# Altium schematic sheet 1-Top.SchDoc(1-Top)
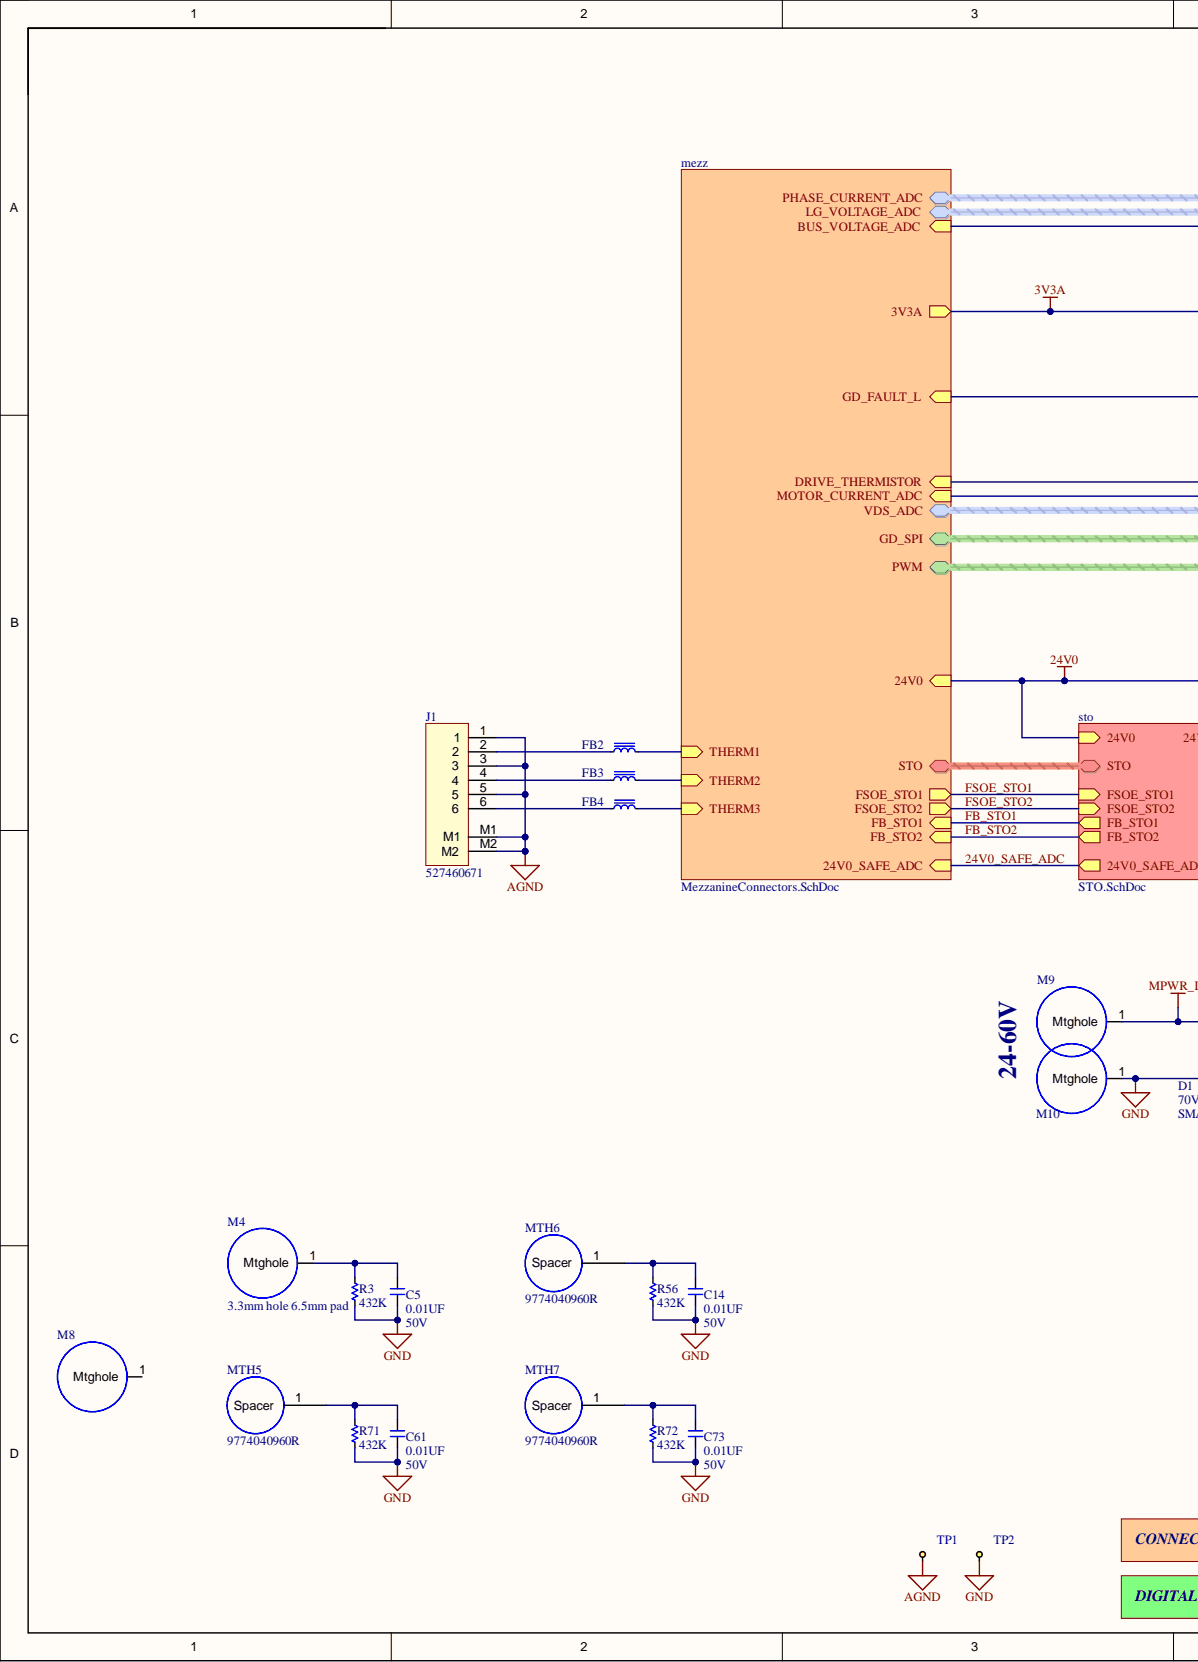
[diagram p0: 1-Top.SchDoc, left]
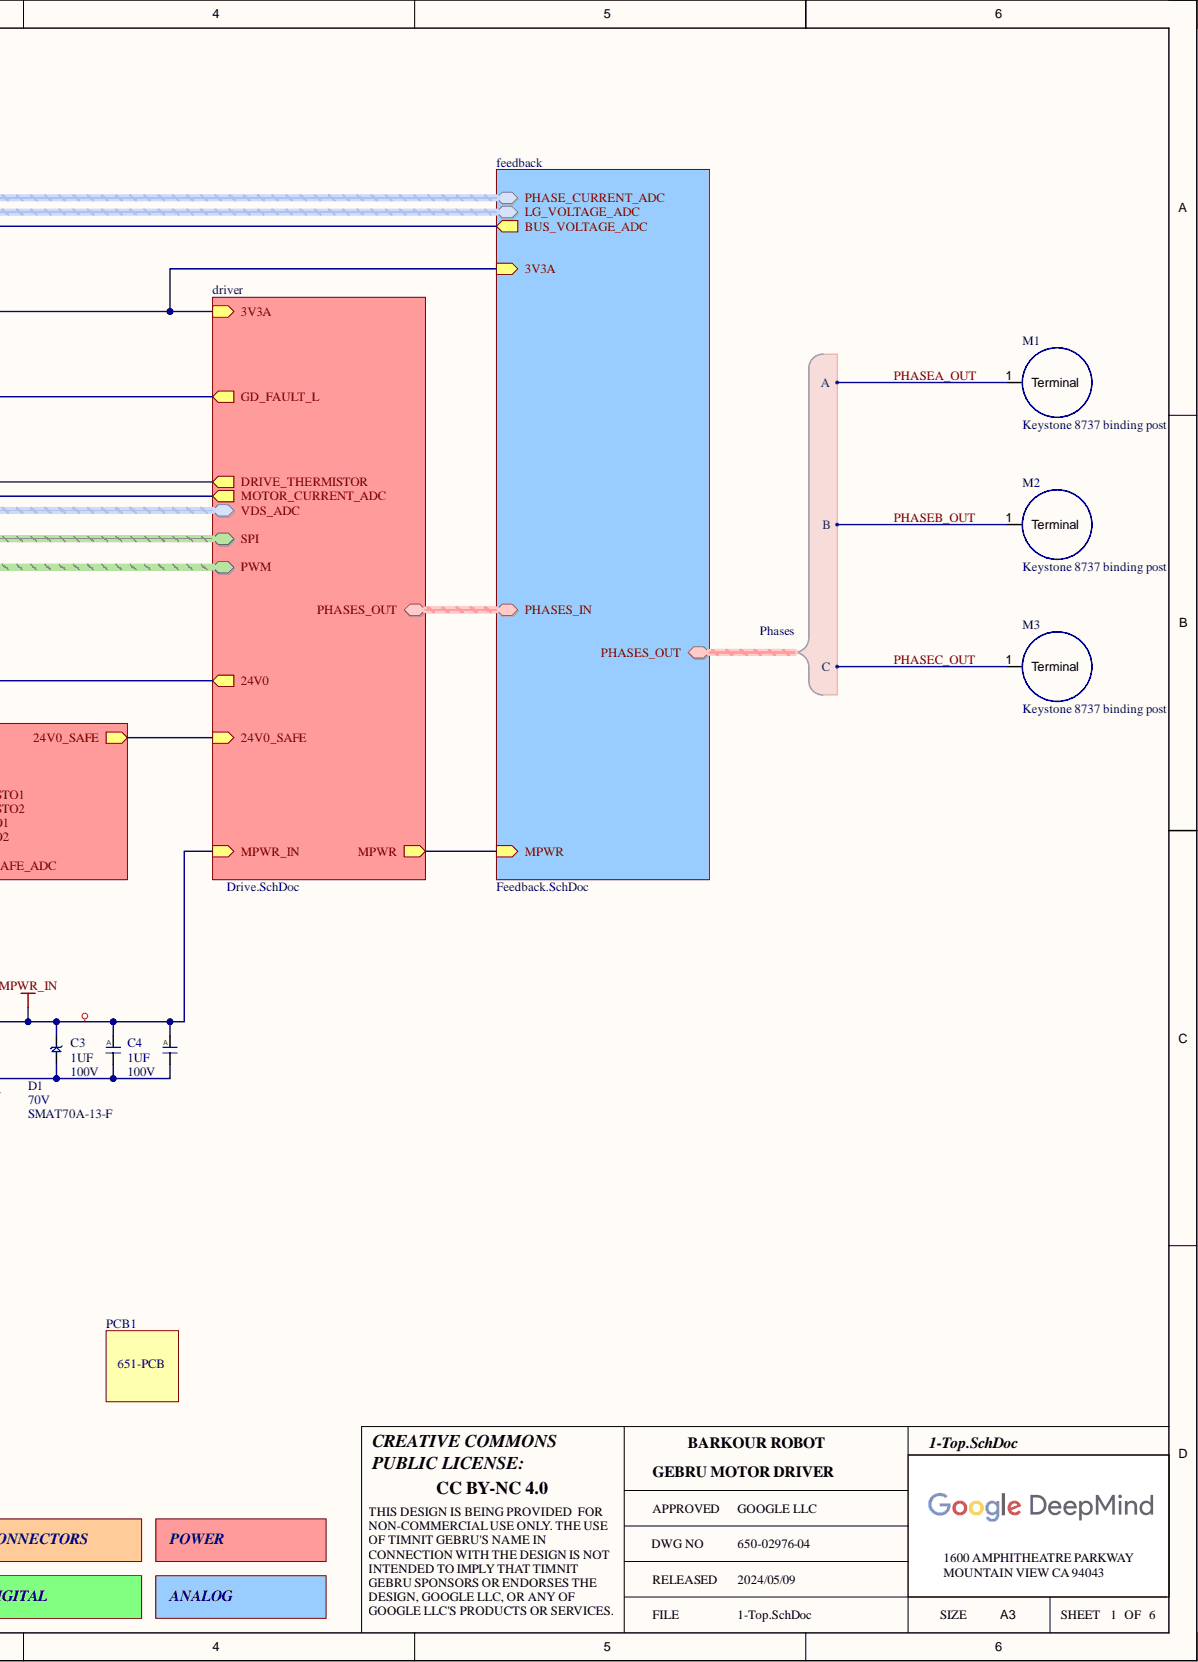
[diagram p1: 1-Top.SchDoc, right]

## Parts
C3  value 1UF  Voltage: 100V  [C0805]  (hidden comment: __Template_Cap_Auto)
C4  value 1UF  Voltage: 100V  [C0805]  (hidden comment: __Template_Cap_Auto)
C5  value 0.01UF  Voltage: 50V  [C0402]  (hidden comment: __Template_Cap)
C14  value 0.01UF  Voltage: 50V  [C0402]  (hidden comment: __Template_Cap)
C61  value 0.01UF  Voltage: 50V  [C0402]  (hidden comment: __Template_Cap)
C73  value 0.01UF  Voltage: 50V  [C0402]  (hidden comment: __Template_Cap)
D1  value SMAT70A-13-F  Voltage - Clamping (Max) @ Ipp: 100V  Voltage - DC Reverse (VR) (MAX): 70V  [SMA_B130-13-F]  (hidden comment: __Template_Diode_TVS_A/K)
FB2  LAIRD MI0603L221R-10  [INDC160X80X105L30N]  (value not drawn)
FB3  LAIRD MI0603L221R-10  [INDC160X80X105L30N]  (value not drawn)
FB4  LAIRD MI0603L221R-10  [INDC160X80X105L30N]  (value not drawn)
J1  MFG P/N: 527460671  [MOLEX_FPC_527460671]
M1  Keystone 8737 binding post  [Keystone_8737]  (no BOM)
M2  Keystone 8737 binding post  [Keystone_8737]  (no BOM)
M3  Keystone 8737 binding post  [Keystone_8737]  (no BOM)
M4  3.3mm hole 6.5mm pad  [3.3HOLE6.5PAD]  (no BOM)
M8  3.7mm hole 5mm pad  [3.7hole5pad]  (value not drawn)
M9  1.3mm hole 5mm pad  [1.7hole5padSQ]  (value not drawn)  (no BOM)
M10  1.3mm hole 5mm pad  [1.7hole5padRD]  (value not drawn)  (no BOM)
MTH5  9774040960R  [9774040960R]
MTH6  9774040960R  [9774040960R]
MTH7  9774040960R  [9774040960R]
PCB1  (no value)  (mechanical)
R3  value 432K  [R0805]
R56  value 432K  [R0805]
R71  value 432K  [R0805]
R72  value 432K  [R0805]
TP1  TEST_KEYSTONE5001  [TP_KEYSTONE_5001]  (value not drawn)
TP2  TEST_KEYSTONE5001  [TP_KEYSTONE_5001]  (value not drawn)

## Nets
AGND: J1.1 J1.3 J1.5 J1.M1 J1.M2 TP1.1
GND: C3.2 C4.2 C5.2 C14.2 C61.2 C73.2 D1.A M10.1 R3.1 R56.1 R71.1 R72.1 TP2.1
MPWR_IN: C3.1 C4.1 D1.K M9.1
PHASEA_OUT: M1.1
PHASEB_OUT: M2.1
PHASEC_OUT: M3.1
NetC5_1: C5.1 M4.1 R3.2
NetC14_1: C14.1 MTH6.1 R56.2
NetC61_1: C61.1 MTH5.1 R71.2
NetC73_1: C73.1 MTH7.1 R72.2
NetFB2_1: FB2.1 J1.2
NetFB2_2: FB2.2
NetFB3_1: FB3.1 J1.4
NetFB3_2: FB3.2
NetFB4_1: FB4.1 J1.6
NetFB4_2: FB4.2
NetM8_1: M8.1
3V3A:
24V0:
24V0_SAFE_ADC:
FB_STO1:
FB_STO2:
FSOE_STO1:
FSOE_STO2:
N00001:
N00002:
N00003:
N00004:
N00005:
N00006:
N00007:
N00008:
N00009:
N00010:
N00011:
N00012:
N00013:
N00014:
N00015:
N00016:
N00017:
N00018:
N00019:
N00020:
N00021:
N00022:
N00023:
N00024:
N00025:
N00026:
N00027:
N00028:
N00029:
N00030:
N00031:
N00032:
N00033:

## Library data of the parts
C3 C4 = 1093: CAP, CER, X7S, 1uF, 20%, 100V, 0805; COMMON: 150-01093-00; Database Table Name: Capacitors; EES: 150-04579-00; MFG: TDK; MFG P/N: CGA4J3X7S2A105M125AE; PROXY: 150-03473-00; XPN: XS-10001040; Component Kind: Standard; ComponentLink1Description: Datasheet; Dielectric: X7S; Gecko: 150-01093-00; Height: 1.5mm T; ID: 1093; Library Name: AltiumReleaseLibDB.DBLib; LOON: 150-01093-00; Package: 0805; Status: Released; Tolerance: 20%
C5 C14 C61 C73 = 625: CAP, CER, X7R, 0.01uF, 50V, 20%, 0402, 0.56mm T; COMMON: 150-00227-00; Database Table Name: Capacitors; EES: 150-04592-00; MFG: KEMET; MFG P/N: C0402C103M5RACTU; Component Kind: Standard; ComponentLink1Description: Datasheet; Dielectric: X7R; Gecko: 150-00227-00; Height: 0.56mm T; ID: 625; Library Name: AltiumReleaseLibDB.DBLib; LOON: 150-00227-00; Package: 0402; Status: Released; Tolerance: 20%; XPN: 150-00227-00
D1 = 200: DIODE, TVS, UNI-DIR, 70VR, 100VCL, 400W, 140PF @1MHZ, SMA; Database Table Name: Diodes; MFG: Diodes Incorporated; PROXY: 480-01326-00; Category: Diode; Component Kind: Standard; ComponentLink1Description: Datasheet; ComponentLink1URL: https://www.diodes.com/assets/Datasheets/ds30213.pdf; Diode Type: TVS; HelpURL: https://www.digikey.in/product-detail/en/diodes-incorporated/SMAT70A-13-F/SMAT70A-FDICT-ND/804910; ID: 200; Library Name: AltiumReleaseLibDB.DBLib; MFG P/N: SMAT70A-13-F; Mounting Type: SMD; Operating Temperature – Junction: -55°C ~ 150°C (TJ); Package: SMA; RoHS Compliant: Yes; Status: Released; Supplier 1: Digi-Key; Supplier Part Number 1: SMAT70A-FDICT-ND
FB2-FB4 = f5bd4cf1-070f-4480-bf1a-f8cba0e78574: FERRITE BEAD 220 OHM 0603 1LN; Manufacturer: Laird Technologies; Current Rating: 2A; Database Table Name: Filters; Grade: Commercial; Value: 220 Ohms @ 100 MHz; Category: Ferrite Beads; Component Kind: Standard; ComponentId: f5bd4cf1-070f-4480-bf1a-f8cba0e78574; ComponentLink1Description: Datasheet; ComponentLink1URL: https://media.digikey.com/pdf/Data%20Sheets/Laird%20Technologies/EMI_Filtering_and_RF_Inductors.pdf; Library Name: Components.DbLib; Manufacturer Part Number: MI0603L221R-10; Operating Temperature: -40 °C ~ 125 °C; Package: Inductor, 0603; Status: Preliminary; Storage Temperature: -40 °C ~ 125 °C
J1 = 1210: CONN, FFC, RA, 1x6 P, 0.5MM PITCH, 2.3MM H, GOLD, SMD; Current Rating: 0.5A; Database Table Name: Connectors; EES: 210-04996-00; MFG: Molex; Number of Positions: 6; Sub Assy Qty 2: 0; Voltage, Rated: 50V; Component Kind: Standard; ComponentLink1Description: Datasheet; ComponentLink1URL: https://www.molex.com/pdm_docs/sd/527460671_sd.pdf; Connector Type: FFC; Features: Contacts, Bottom; Height: 2.3mm; HelpURL: https://www.digikey.com/en/products/detail/molex/0527460671/2074148?s=N4IgTCBcDaIKxgOwBYBsAGViCMIC6AvkA; ID: 1210; Library Name: AltiumReleaseLibDB.DBLib; Mounting Type: Surface Mount; Number of Rows: 1; Operating Temperature: -20°C ~ 85°C; Orientation: Right Angle; Pitch: 0.5mm; RoHS Compliant: Yes; Status: Released; Sub Assy Qty 3: 0; Sub Assy Qty 4: 0; Supplier 1: Digi-Key; Supplier Part Number 1: WM7977TR-ND
M1-M3 = Keystone_8737_post: 1.5mm hole 5.6mm pad; Library Name: MiscSch1.SchLib
M4 = MtgHole_2: Description: 3.3mm hole 6.5mm pad; Library Name: MiscSch1.SchLib
M8 = MtgHole: Component Kind: Standard; Description: 3.7mm hole 5mm pad; Library Name: MiscSch1.SchLib
M9 M10 = MtgHole_1: Description: 1.3mm hole 5mm pad; Library Name: MiscSch1.SchLib
MTH5-MTH7 = Wurth_4mm_Spacer_9774040960R: Spacer Material: Steel Mounting: SMT Series: WA-SMST For ø: 3.3 mm; Manufacturer: Wurth Electronics; Inside Diameter: 0.13inch; Outside Diameter: 0.236inch; Plating: Tin; Threaded/Unthreaded: Unthreaded; Category: Spacers, Standoffs; Component Kind: Standard; ComponentLink1Description: http://www.farnell.com/datasheets/1960394.pdf?src-supplier=Farnell; ComponentLink1URL: http://www.farnell.com/datasheets/1960394.pdf?src-supplier=Farnell; ComponentLink2Description: http://www.mouser.com/ds/2/445/9774040960R-611108.pdf?src-supplier=Mouser; ComponentLink2URL: http://www.mouser.com/ds/2/445/9774040960R-611108.pdf?src-supplier=Mouser; ComponentLink3Description: https://media.digikey.com/pdf/Data%20Sheets/Wurth%20Electronics%20PDFs/WA-SMSx_Performance_Flyer.pdf?src-supplier=Digi-Key; ComponentLink3URL: https://media.digikey.com/pdf/Data%20Sheets/Wurth%20Electronics%20PDFs/WA-SMSx_Performance_Flyer.pdf?src-supplier=Digi-Key; ComponentLink4Description: http://www.farnell.com/datasheets/1960394.pdf?src-supplier=Element14; ComponentLink4URL: http://www.farnell.com/datasheets/1960394.pdf?src-supplier=Element14; ComponentLink5Description: http://www.farnell.com/datasheets/1960394.pdf?src-supplier=Newark; ComponentLink5URL: http://www.farnell.com/datasheets/1960394.pdf?src-supplier=Newark; Length: 0.213inch; Library Name: MiscSch1.SchLib; Manufacturer Part Number: 9774040960R; Material: Steel; Pricing 1: 1=1.79000, 10=1.71600, 50=1.50700, 100=1.40200 (USD); REACH SVHC: Unknown; RoHS: Yes; Stock 1: 190; Supplier 1: Digi-Key; Supplier Part Number 1: 732-7092-1-ND
PCB1 = 110: PCB; Database Table Name: Miscellaneous; FindNumber: 010; Level: 1; Org: ORG - REP; ID: 110; Library Name: AltiumReleaseLibDB.DBLib; ProductLine: Proxy; Status: In Development; Type: PCB
R3 R56 R71 R72 = 5492: Resistor, 432K Ohms, 0805, 0.125W, ±1%; COMMON: 470-08723-00; Database Table Name: Resistors; MFG: YAGEO; MFG P/N: RC0805FR-07432KL; Power: 1/8W; XPN: XS-10019230; Component Kind: Standard; Gecko: 470-08723-00; Height: 0.60mm; ID: 5492; Library Name: AltiumReleaseLibDB.DBLib; LOON: 470-08723-00; Package: 0805; Status: Released; Supplier 1: Digi-Key; Supplier Part Number 1: 311-432KCRCT-ND; Tolerance: 1%
TP1 TP2 = TEST_KEYSTONE5001: PC TEST POINT TH RING; Manufacturer: Keystone Electronics; Manufacturer Part Number: 5001; Part Number: 250-00184-00; Category: Test and Measurement; Color: Black; Component Kind: Standard; ComponentLink1Description: http://www.keyelco.com/product-pdf.cfm?p=1310; ComponentLink1URL: http://www.keyelco.com/product-pdf.cfm?p=1310; Library Name: miscellaneous.SchLib; Material: Base, Nylon 46, UL 94V-0; Mounting Type: 0.040" (1.02mm) Hole Diameter; Packaging: Bulk; Pricing 1: 1=0.33, 10=0.309, 50=0.2252, 100=0.2164, 250=0.19432, 500=0.18548, 1000=0.15456, 2500=0.14131, 5000=0.13248 (USD); RoHS: RoHS Compliant; Stock 1: 341830; Supplier 1: Digi-Key; Supplier Part Number 1: 5001K-ND; Terminal: 0.010" x 0.020" (0.25mm x 0.51mm) Phosphor Bronze Wire, Silver Plate; Type: PC Test Point, Miniature
